# Altium schematic sheet power2.SchDoc(power2)
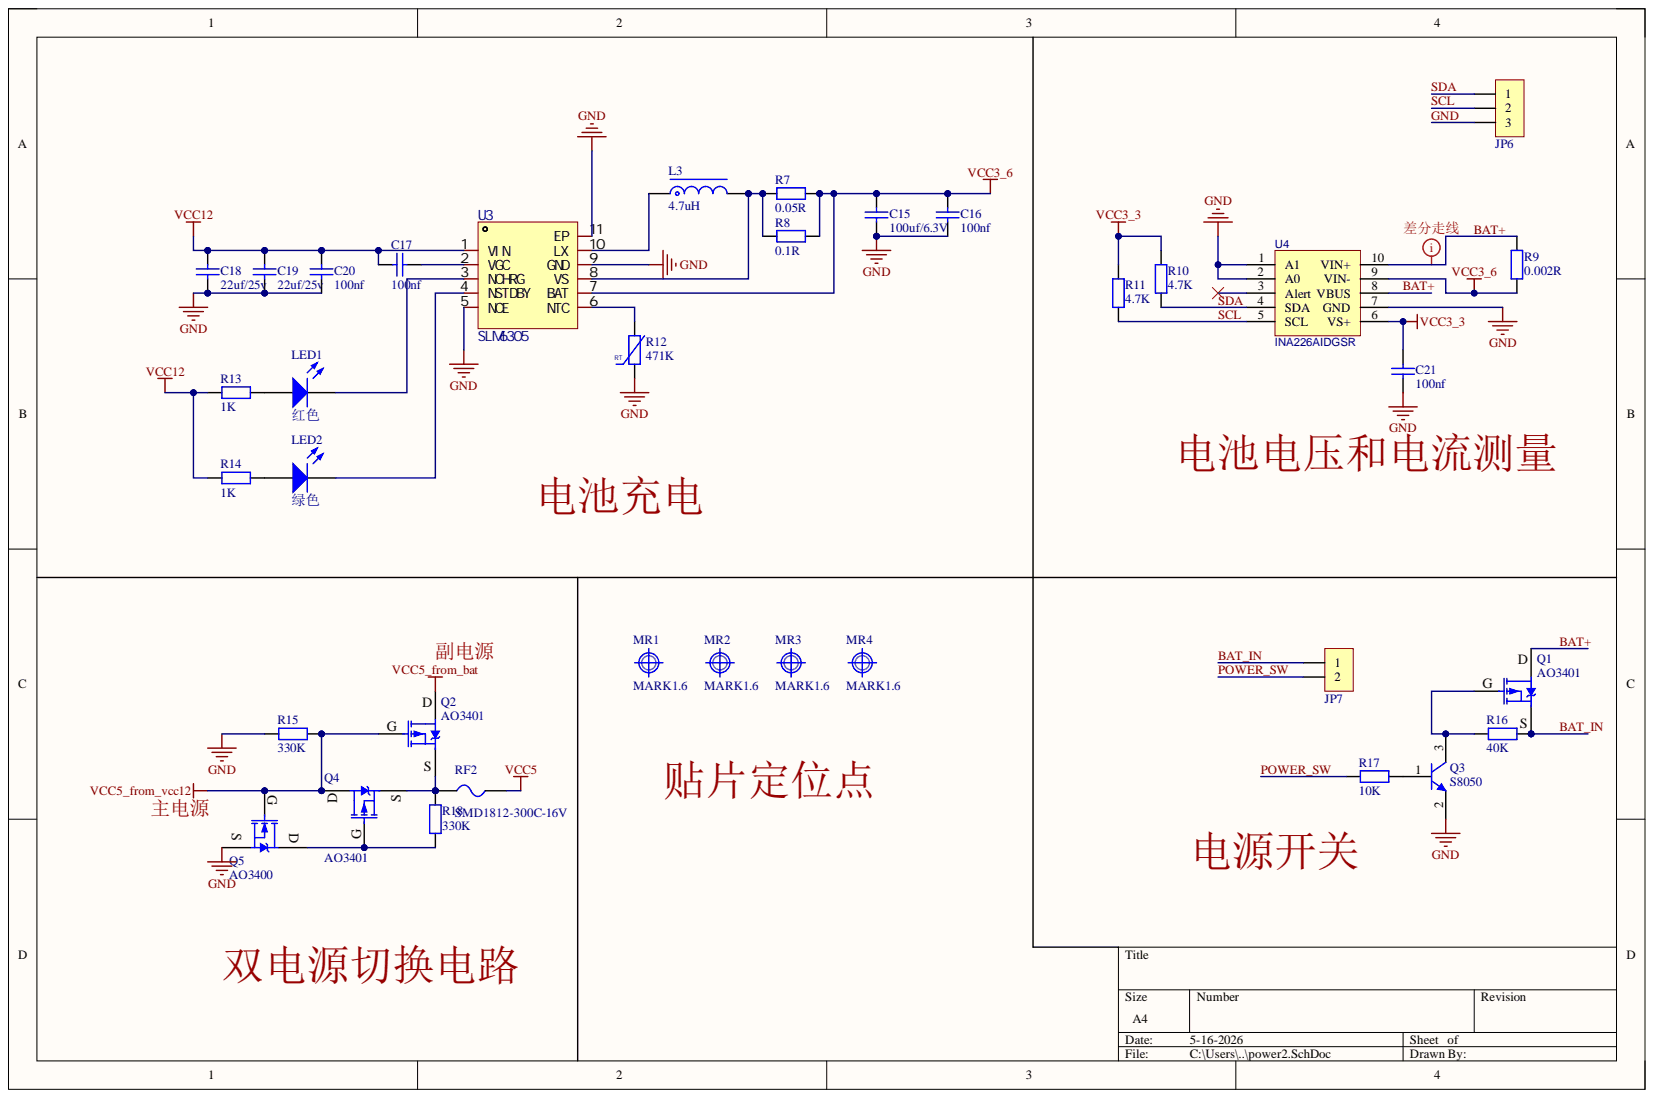
[diagram p0: power2.SchDoc]

## Parts
C15  100uf/6.3V  [C1206_L]
C16  100nf  [C0603_L]
C17  100nf  [C0603_L]
C18  22uf/25v  [C0805_L]
C19  22uf/25v  [C0805_L]
C20  100nf  [C0603_L]
C21  100nf  [C0603_L]
JP6  HDR-1X3  [HDR2.54-LI-3P]  (value not drawn)
JP7  HDR-1X2  [PH2.0-W-2P]  (value not drawn)
L3  4.7uH  [CD53]
LED1  红色  [LED0603_Y]
LED2  绿色  [LED0603_Y]
MR1  MARK1.6  [Mark 1]
MR2  MARK1.6  [Mark 1]
MR3  MARK1.6  [Mark 1]
MR4  MARK1.6  [Mark 1]
Q1  AO3401  [SOT23-3N]
Q2  AO3401  [SOT23-3N]
Q3  S8050  [SOT23-3M]
Q4  AO3401  [SOT23-3N]
Q5  AO3400  [SOT23-3N]
R7  0.05R  [R1206_L]
R8  0.1R  [R1206_L]
R9  0.002R  [R2512_M]
R10  4.7K  [R0603_L]
R11  4.7K  [R0603_L]
R12  471K  [PK0406]
R13  1K  [R0603_L]
R14  1K  [R0603_L]
R15  330K  [R0603_L]
R16  40K  [R0603_L]
R17  10K  [R0603_L]
R18  330K  [R0603_L]
RF2  SMD1812-300C-16V  [F1812]
U3  SLM6305  [DFN10-L3.0-W3.0-P0.5_EP]
U4  INA226AIDGSR  [MSOP10_N]

## Nets
VCC3_3: C21.2 R10.2 R11.2 U4.6(VS+)
VCC3_6: C15.2 C16.2 R7.2 R8.2 R9.2 U3.7(BAT) U4.9(VIN-)
VCC5: RF2.1
VCC5_from_bat: Q2.3
VCC5_from_vcc12: Q2.1 Q4.3 Q5.1 R15.1
VCC12: C17.2 C18.2 C19.2 C20.1 R13.1 R14.1 U3.1(VIN)
BAT+: Q1.3 R9.1 U4.8(VBUS) U4.10(VIN+)
BAT_IN: JP7.1 Q1.2 R16.2
GND: C15.1 C16.1 C18.1 C19.1 C20.2 C21.1 JP6.3 Q3.2 Q5.2 R12.1 R15.2 U3.5(NCE) U3.9(GND) U3.11(EP) U4.1(A1) U4.2(A0) U4.7(GND)
POWER_SW: JP7.2 R17.1
SCL: JP6.2 R11.1 U4.5(SCL)
SDA: JP6.1 R10.1 U4.4(SDA)
NetC17_1: C17.1 U3.2(VGC)
NetL3_1: L3.1 U3.10(LX)
NetL3_2: L3.2 R7.1 R8.1 U3.8(VS)
NetLED1_1: LED1.1 R13.2
NetLED1_2: LED1.2 U3.3(NCHRG)
NetLED2_1: LED2.1 R14.2
NetLED2_2: LED2.2 U3.4(NSTDBY)
NetQ1_1: Q1.1 Q3.3 R16.1
NetQ2_2: Q2.2 Q4.2 R18.2 RF2.2
NetQ3_1: Q3.1 R17.2
NetQ4_1: Q4.1 Q5.3 R18.1
NetR12_2: R12.2 U3.6(NTC)

Not connected: U4 3(Alert)

## Library data of the parts
C15-C21 = C: 贴片电容/MLCC电容; BOM名称: 无极性贴片电容; 联系下单店铺卖家 免费帮你查找封装: 联系下单店铺卖家 免费技术指导; Component Kind: Standard; Library Name: 0.1 - 电阻-电容-电感-保险丝-晶振-晶体-磁珠-电位器-法拉电容-馈通电容.SchLib
  C15 C18 C19: jlc_id: C45783
JP6 = Header 3: 3P接插件; 封装库来自淘宝卖家ID：黄晶宝石: 其他店铺购入均为盗版封装库，请立即申请退款，本店现金奖励50~200元; 联系卖家，免费查找封装: 唯一出售店铺网址https://shop463601444.taobao.com; BOM名称: 3P接插件; Component Kind: Standard; Library Name: 5.5 - 2.54mm连接器(KF2EDGK_XH2.54_2.54排针排母).SchLib
JP7 = Header 2: 2P接插件; 封装库来自淘宝卖家：黄晶宝石: 获取最新版本https://shop463601444.taobao.com; 联系下单店铺卖家 免费帮你查找封装: 联系下单店铺卖家 免费技术支持; BOM名称: 2P接插件; Component Kind: Standard; Library Name: 5.4 - 2.0mm连接器(PHB2.0_PHD2.0_2.0排针排母).SchLib
L3 = L-SMD: CD系列贴片线绕功率电感; BOM名称: 功率电感; Component Kind: Standard; jlc_id: C177246; Library Name: 0.1 - 电阻-电容-电感-保险丝-晶振-晶体-磁珠-电位器-法拉电容-馈通电容.SchLib
LED1 LED2 = LED: 贴片LED; 封装库来自淘宝卖家：黄晶宝石: 其他店铺购入均为盗版封装库，无更新权益，请立即申请退款，本店现金奖励200~1000元; BOM名称: 贴片LED; Component Kind: Standard; Library Name: 0.3 - LED发光二极管-IR二极管_接收管-光耦.SchLib
MR1-MR4 = MARK: 光学定位点; 联系下单店铺卖家 免费技术支持: 联系下单店铺卖家 免费帮你查找封装; BOM名称: 光学定位点; Component Kind: Standard; Library Name: 0.5 - 按键_开关_蜂鸣器_电池_测试点_Mark点_铜柱.SchLib
Q1 Q2 Q4 = AO3401: P沟道 30V/4.2A; BOM名称: 场效应管; 当前库版本V2024.2.3 @淘宝卖家: 最新版本发布https://item.taobao.com/item.htm?id=566775463719; 联系下单店铺卖家 免费帮你查找封装: 联系下单店铺卖家 免费技术支持; Component Kind: Standard; jlc_id: C347476; Library Name: 0.4 - 三极管-场效应管-可控硅.SchLib; 参数: P沟道 30V/4.2A
Q3 = BCP68: NPN三极管; 当前库版本V2024.2.3 @淘宝卖家: 最新版本发布https://item.taobao.com/item.htm?id=566775463719; 联系下单店铺卖家 免费帮你查找封装: 联系下单店铺卖家 免费技术支持; Component Kind: Standard; Library Name: 0.4 - 三极管-场效应管-可控硅.SchLib
Q5 = AO3400: N沟道 30V/4.2A; BOM名称: 场效应管; 当前库版本V2024.2.3 @淘宝卖家: 最新版本发布https://item.taobao.com/item.htm?id=566775463719; 联系下单店铺卖家 免费帮你查找封装: 联系下单店铺卖家 免费技术支持; Component Kind: Standard; jlc_id: C20917; Library Name: 0.4 - 三极管-场效应管-可控硅.SchLib; 参数: N沟道 30V/4.2A
R7-R11 R13-R18 = R: 贴片电阻; 联系下单店铺卖家 免费帮你查找封装: 联系下单店铺卖家 免费技术指导; BOM名称: 贴片电阻; Component Kind: Standard; Library Name: 0.1 - 电阻-电容-电感-保险丝-晶振-晶体-磁珠-电位器-法拉电容-馈通电容.SchLib
  R7: jlc_id: C127691
  R8: jlc_id: C25334
  R9: jlc_id: C2994640
R12 = RT: 热敏电阻; BOM名称: 压敏电阻; 当前库版本V2024.3.30 @淘宝卖家: 最新版本发布https://item.taobao.com/item.htm?id=566775463719; Component Kind: Standard; Library Name: 0.1 - 电阻-电容-电感-保险丝-晶振-晶体-磁珠-电位器-法拉电容-馈通电容.SchLib
RF2 = FUSE-PPTC: PPTC自恢复保险丝; 当前库版本V2024.3.30 @淘宝卖家: 最新版本发布https://item.taobao.com/item.htm?id=566775463719; BOM名称: PPTC自恢复保险丝; Component Kind: Standard; jlc_id: C2760295; Library Name: 0.1 - 电阻-电容-电感-保险丝-晶振-晶体-磁珠-电位器-法拉电容-馈通电容.SchLib
U3 = SLM6305: 1锂电池充电ic 2.5a 4.2v 4V~26V输入; Manufacturer: 无锡松朗微; Add into BOM: yes; Number of Cells: 1; Supply Voltage: 4V~26V; The Chip Type: 降压充电芯片; Type of Battery: 锂离子/聚合物; Charging Saturation Voltage: 4.2V; Component Kind: Standard; Convert to PCB: yes; Datasheet: https://atta.szlcsc.com/upload/public/pdf/source/20200723/C711584_2224278DBAF55B7B34A102BEBD70C238.pdf; Device: SLM6305; jlc_id: C711584; JLCPCB Part Class: 扩展库; LCSC Part Name: 宽输入电压2.5A单节锂电池充电管理IC; Library Name: HT.SchLib; Manufacturer Part: SLM6305; Maximum Charge Current: 2.5A; Operating Temperature: -40℃~+85℃; Supplier: LCSC; Supplier Footprint: TDFN-10-EP(3x3); Symbol: SLM6305
U4 = INA226: 双向电流/功率监视器芯片; 封装库来自淘宝卖家：黄晶宝石: 其他店铺购入均为盗版封装库，无更新权益，请立即申请退款，联系卖家：黄晶宝石 获取现金奖励200~5000元; Component Kind: Standard; Library Name: 0.8 - 常见芯片.SchLib
